# Altium schematic sheet 03_Ti60F225_Power.SchDoc
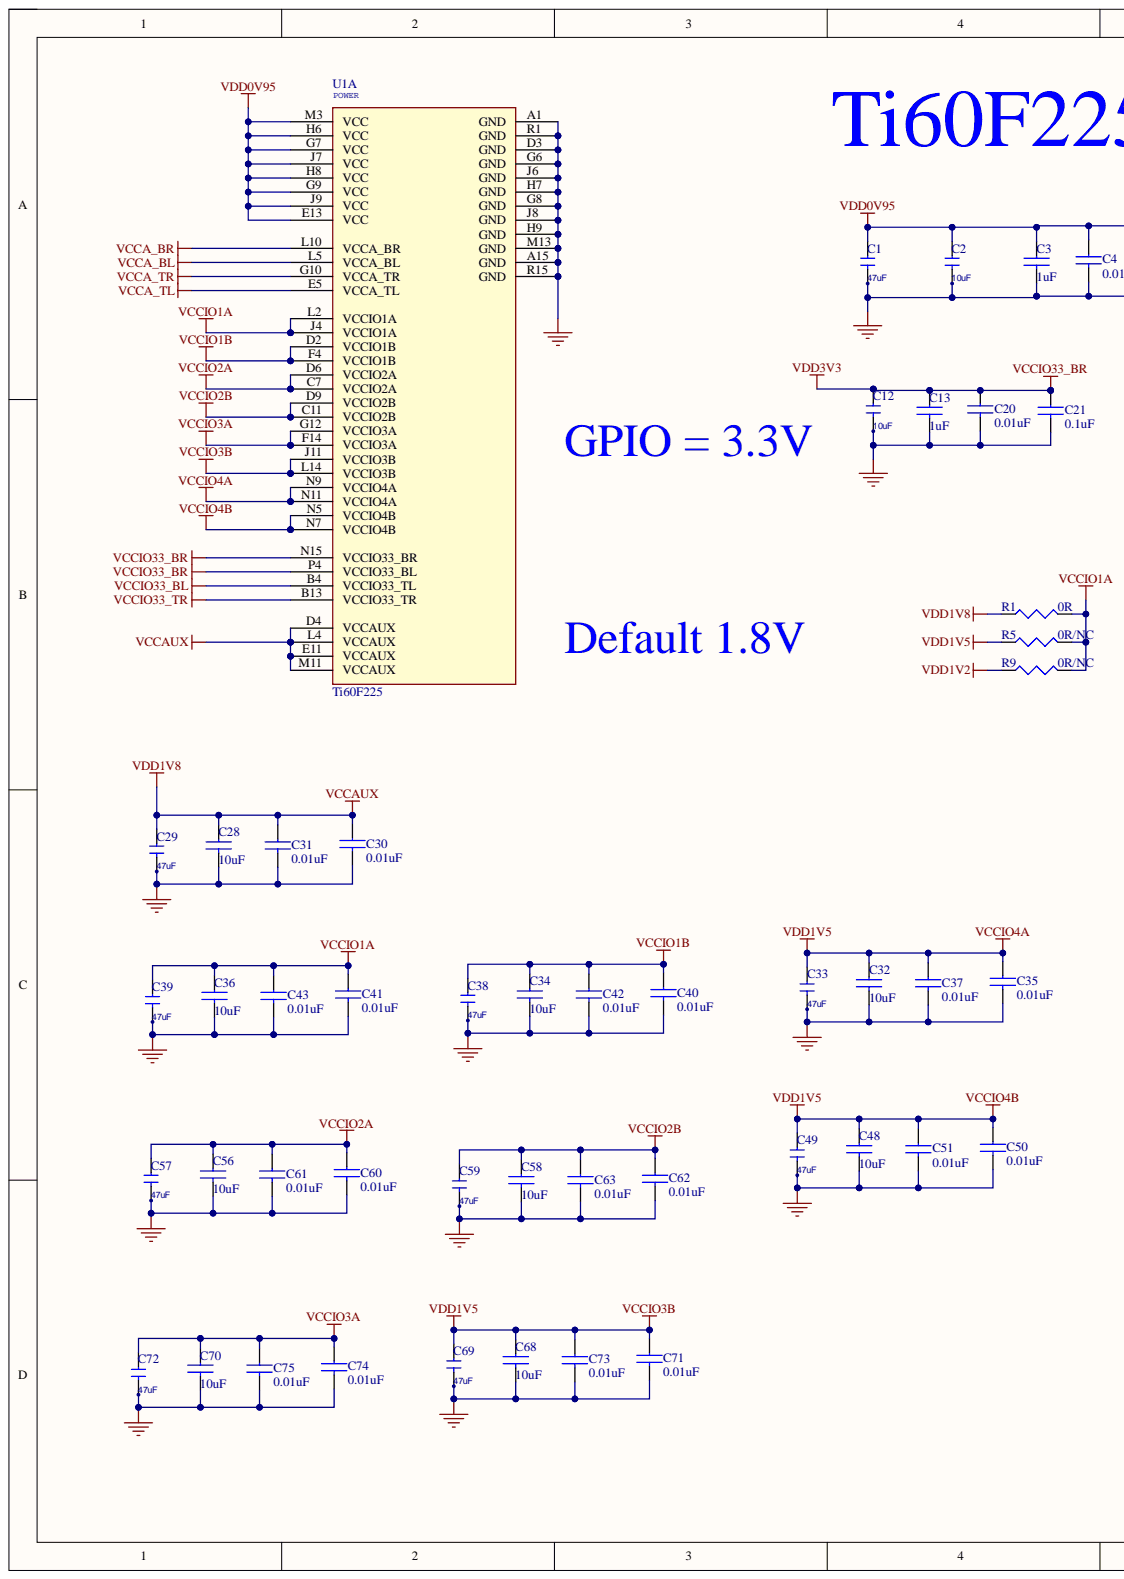
[diagram p0: 03_Ti60F225_Power.SchDoc, left]
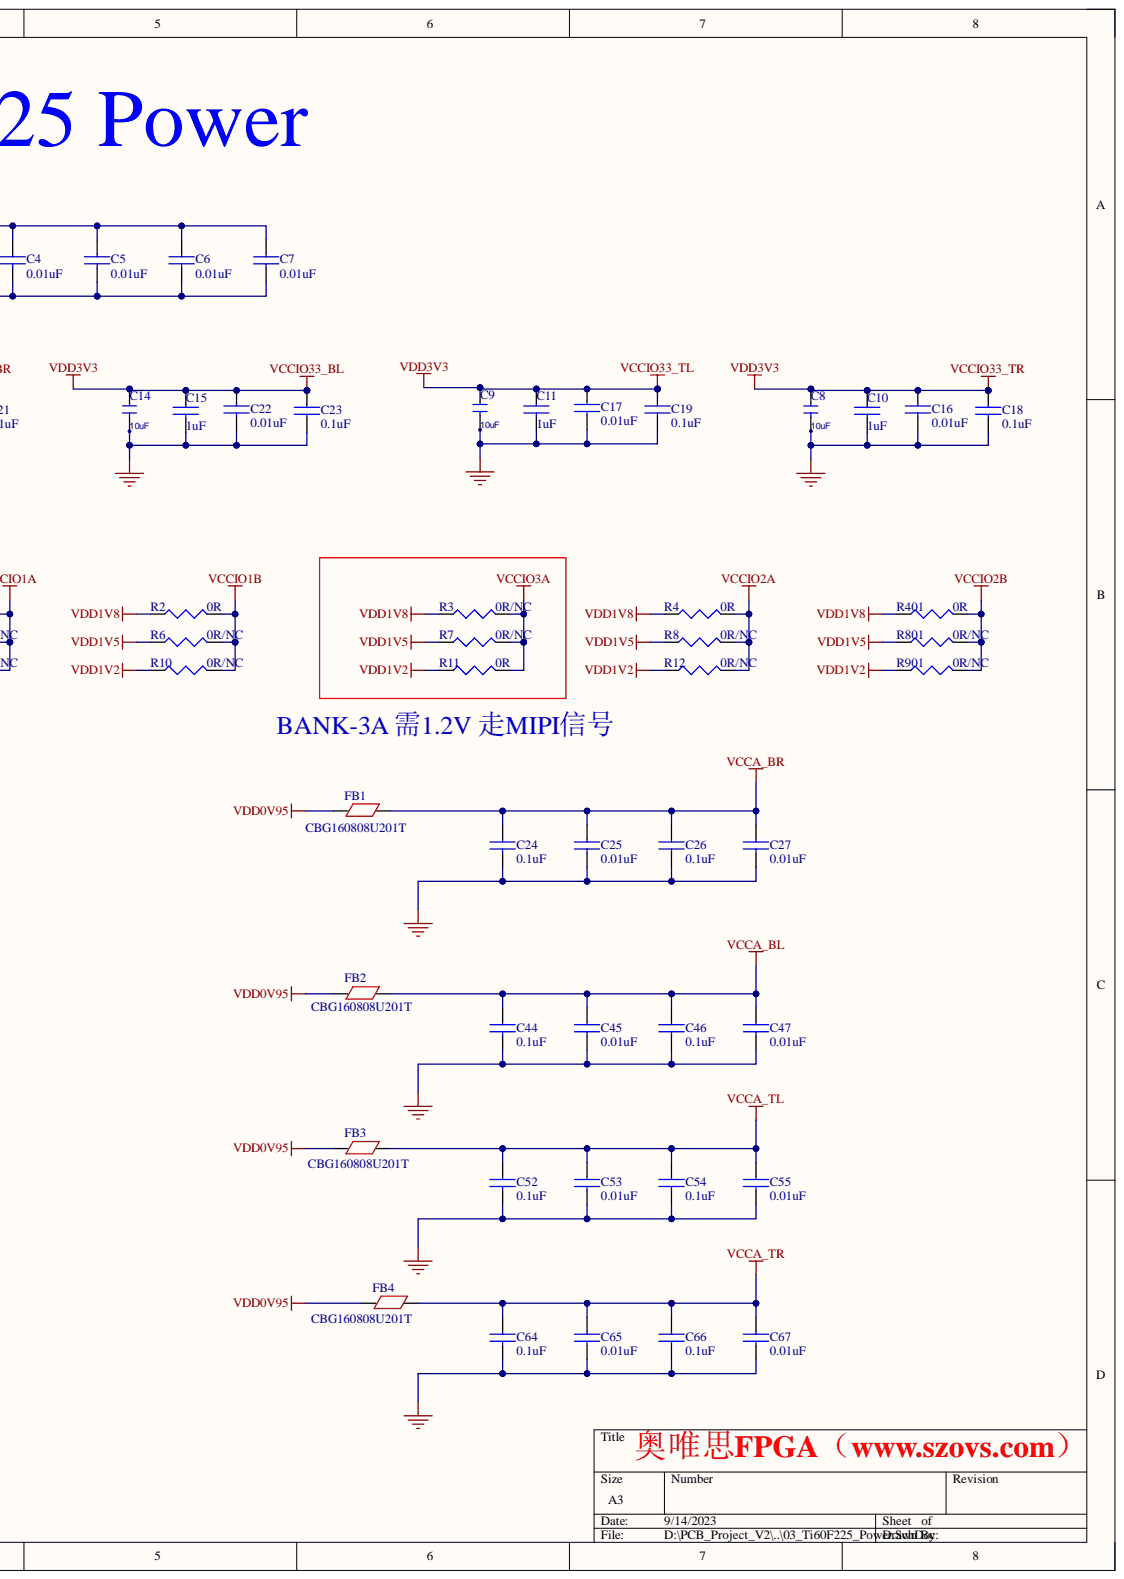
[diagram p1: 03_Ti60F225_Power.SchDoc, right]

## Parts
C1  47uF  [R0805]
C2  10uF  [R0603]
C3  1uF  [R0402]
C4  0.01uF  [R0402]
C5  0.01uF  [R0402]
C6  0.01uF  [R0402]
C7  0.01uF  [R0402]
C8  10uF  [R0603]
C9  10uF  [R0603]
C10  1uF  [R0402]
C11  1uF  [R0402]
C12  10uF  [R0603]
C13  1uF  [R0402]
C14  10uF  [R0603]
C15  1uF  [R0402]
C16  0.01uF  [R0402]
C17  0.01uF  [R0402]
C18  0.1uF  [R0402]
C19  0.1uF  [R0402]
C20  0.01uF  [R0402]
C21  0.1uF  [R0402]
C22  0.01uF  [R0402]
C23  0.1uF  [R0402]
C24  0.1uF  [R0402]
C25  0.01uF  [R0402]
C26  0.1uF  [R0402]
C27  0.01uF  [R0402]
C28  10uF  [R0603]
C29  47uF  [R0805]
C30  0.01uF  [R0402]
C31  0.01uF  [R0402]
C32  10uF  [R0603]
C33  47uF  [R0805]
C34  10uF  [R0603]
C35  0.01uF  [R0402]
C36  10uF  [R0603]
C37  0.01uF  [R0402]
C38  47uF  [R0805]
C39  47uF  [R0805]
C40  0.01uF  [R0402]
C41  0.01uF  [R0402]
C42  0.01uF  [R0402]
C43  0.01uF  [R0402]
C44  0.1uF  [R0402]
C45  0.01uF  [R0402]
C46  0.1uF  [R0402]
C47  0.01uF  [R0402]
C48  10uF  [R0603]
C49  47uF  [R0805]
C50  0.01uF  [R0402]
C51  0.01uF  [R0402]
C52  0.1uF  [R0402]
C53  0.01uF  [R0402]
C54  0.1uF  [R0402]
C55  0.01uF  [R0402]
C56  10uF  [R0603]
C57  47uF  [R0805]
C58  10uF  [R0603]
C59  47uF  [R0805]
C60  0.01uF  [R0402]
C61  0.01uF  [R0402]
C62  0.01uF  [R0402]
C63  0.01uF  [R0402]
C64  0.1uF  [R0402]
C65  0.01uF  [R0402]
C66  0.1uF  [R0402]
C67  0.01uF  [R0402]
C68  10uF  [R0603]
C69  47uF  [R0805]
C70  10uF  [R0603]
C71  0.01uF  [R0402]
C72  47uF  [R0805]
C73  0.01uF  [R0402]
C74  0.01uF  [R0402]
C75  0.01uF  [R0402]
FB1  CBG160808U201T  [R0603]
FB2  CBG160808U201T  [R0603]
FB3  CBG160808U201T  [R0603]
FB4  CBG160808U201T  [R0603]
R1  0R  [R0402]
R2  0R  [R0402]
R3  0R/NC  [R0402]
R4  0R  [R0402]
R5  0R/NC  [R0402]
R6  0R/NC  [R0402]
R7  0R/NC  [R0402]
R8  0R/NC  [R0402]
R9  0R/NC  [R0402]
R10  0R/NC  [R0402]
R11  0R  [R0402]
R12  0R/NC  [R0402]
R401  0R  [R0402]
R801  0R/NC  [R0402]
R901  0R/NC  [R0402]
U1  Ti60F225  [ti60F225]  (part A)

## Nets
GND: C1.2 C2.2 C3.2 C4.2 C5.2 C6.2 C7.2 C8.2 C9.2 C10.2 C11.2 C12.2 C13.2 C14.2 C15.2 C16.2 C17.2 C18.2 C19.2 C20.2 C21.2 C22.2 C23.2 C24.2 C25.2 C26.2 C27.2 C28.2 C29.2 C30.2 C31.2 C32.2 C33.2 C34.2 C35.2 C36.2 C37.2 C38.2 C39.2 C40.2 C41.2 C42.2 C43.2 C44.2 C45.2 C46.2 C47.2 C48.2 C49.2 C50.2 C51.2 C52.2 C53.2 C54.2 C55.2 C56.2 C57.2 C58.2 C59.2 C60.2 C61.2 C62.2 C63.2 C64.2 C65.2 C66.2 C67.2 C68.2 C69.2 C70.2 C71.2 C72.2 C73.2 C74.2 C75.2 U1.A1(GND) U1.A15(GND) U1.D3(GND) U1.G6(GND) U1.G8(GND) U1.H7(GND) U1.H9(GND) U1.J6(GND) U1.J8(GND) U1.M13(GND) U1.R1(GND) U1.R15(GND)
VCCA_BL: C44.1 C45.1 C46.1 C47.1 FB2.2 U1.L5(VCCA_BL)
VCCA_BR: C24.1 C25.1 C26.1 C27.1 FB1.2 U1.L10(VCCA_BR)
VCCA_TL: C52.1 C53.1 C54.1 C55.1 FB3.2 U1.E5(VCCA_TL)
VCCA_TR: C64.1 C65.1 C66.1 C67.1 FB4.2 U1.G10(VCCA_TR)
VCCAUX: C28.1 C29.1 C30.1 C31.1 R1.1 R2.1 R3.1 R4.1 R401.1 U1.D4(VCCAUX) U1.E11(VCCAUX) U1.L4(VCCAUX) U1.M11(VCCAUX)
VCCIO1A: C36.1 C39.1 C41.1 C43.1 R1.2 R5.2 R9.2 U1.J4(VCCIO1A) U1.L2(VCCIO1A)
VCCIO1B: C34.1 C38.1 C40.1 C42.1 R2.2 R6.2 R10.2 U1.D2(VCCIO1B) U1.F4(VCCIO1B)
VCCIO2A: C56.1 C57.1 C60.1 C61.1 R4.2 R8.2 R12.2 U1.C7(VCCIO2A) U1.D6(VCCIO2A)
VCCIO2B: C58.1 C59.1 C62.1 C63.1 R401.2 R801.2 R901.2 U1.C11(VCCIO2B) U1.D9(VCCIO2B)
VCCIO3A: C70.1 C72.1 C74.1 C75.1 R3.2 R7.2 R11.2 U1.F14(VCCIO3A) U1.G12(VCCIO3A)
VCCIO3B: C32.1 C33.1 C35.1 C37.1 C48.1 C49.1 C50.1 C51.1 C68.1 C69.1 C71.1 C73.1 R5.1 R6.1 R7.1 R8.1 R801.1 U1.J11(VCCIO3B) U1.L14(VCCIO3B) U1.N5(VCCIO4B) U1.N7(VCCIO4B) U1.N9(VCCIO4A) U1.N11(VCCIO4A)
VCCIO33_BL: C8.1 C9.1 C10.1 C11.1 C12.1 C13.1 C14.1 C15.1 C16.1 C17.1 C18.1 C19.1 C20.1 C21.1 C22.1 C23.1 U1.B4(VCCIO33_TL) U1.B13(VCCIO33_TR) U1.N15(VCCIO33_BR) U1.P4(VCCIO33_BL)
VDD0V95: C1.1 C2.1 C3.1 C4.1 C5.1 C6.1 C7.1 FB1.1 FB2.1 FB3.1 FB4.1 U1.E13(VCC) U1.G7(VCC) U1.G9(VCC) U1.H6(VCC) U1.H8(VCC) U1.J7(VCC) U1.J9(VCC) U1.M3(VCC)
VDD1V2: R9.1 R10.1 R11.1 R12.1 R901.1

## Library data of the parts
C1 C2 C8 C9 C12 C14 C29 C33 C38 C39 C49 C57 C59 C69 C72 = C0603: Component Kind: Standard; Library Name: 162_Library.SchLib
C3-C7 C10 C11 C13 C15-C28 C30-C32 C34-C37 C40-C48 C50-C56 C58 C60-C68 C70 C71 C73-C75 = C0402: Component Kind: Standard; Library Name: 162_Library.SchLib
FB1-FB4 = Bead: Component Kind: Standard; Library Name: Crazy_PCB.SchLib
R1-R12 R401 R801 R901 = R: Component Kind: Standard; Library Name: 162_Library.SchLib
U1 = Ti60F225: SPLIT_INST: TRUE; SWAP_INFO: (S1+S2+S3+S4+S5+S6+S7+S8+S9+S10+S11+S12+S13); Component Kind: Standard; Library Name: 162_Library.SchLib
